# Altium schematic sheet ChildPCBRocketV4.SchDoc(ChildPCBRocketV4)
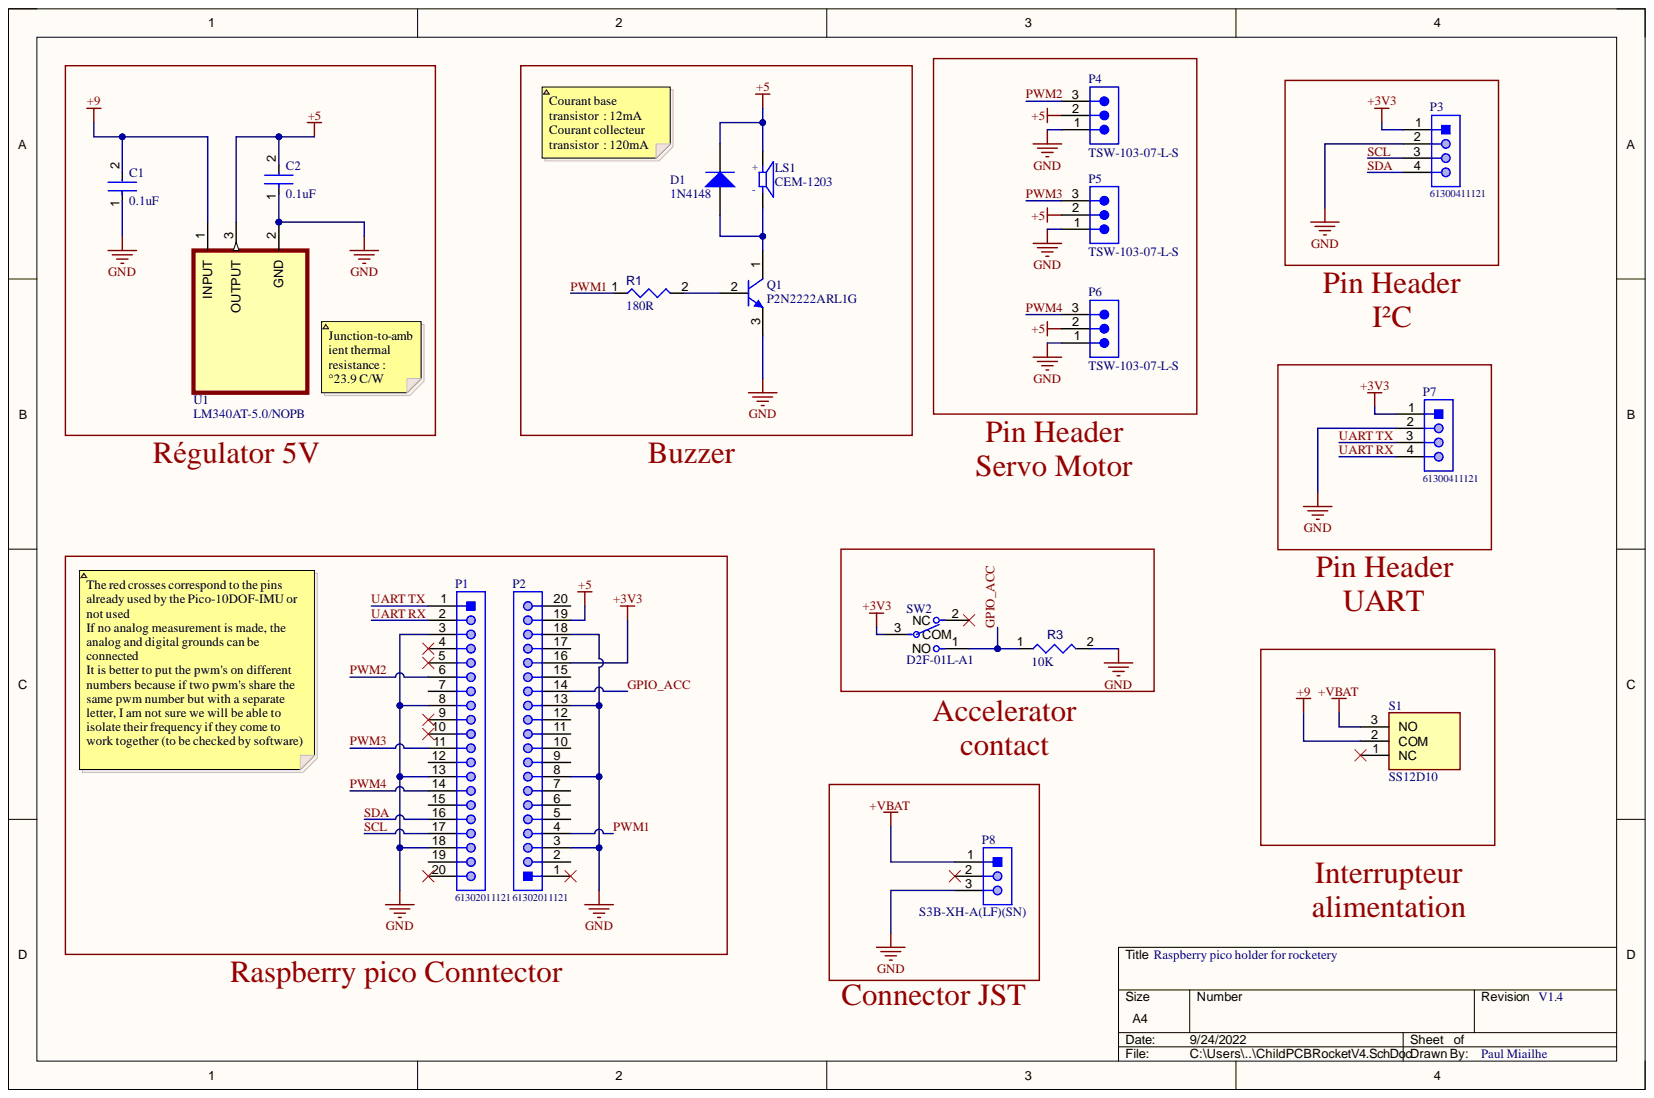
[diagram p0: ChildPCBRocketV4.SchDoc]

## Parts
C1  0.1uF  [FP-RCE1K1-2_5-MFG]
C2  0.1uF  [FP-RCE1K1-2_5-MFG]
D1  1N4148  [NXP-SOD27-2_V]
LS1  CEM-1203  [CUI_CEM-1203(42)]
P1  61302011121  [61302011121]
P2  61302011121  [61302011121]
P3  61300411121  [61300411121]
P4  TSW-103-07-L-S  [SMTC-TSW-103-07-X-S]
P5  TSW-103-07-L-S  [SMTC-TSW-103-07-X-S]
P6  TSW-103-07-L-S  [SMTC-TSW-103-07-X-S]
P7  61300411121  [61300411121]
P8  S3B-XH-A(LF)(SN)  [JST-S3B-XH-A(LF)(SN)_V]
Q1  P2N2222ARL1G  [ONSC-TO-92-3-29-11_AM_BL]
R1  value 180R  [RESA60-630X240]  (hidden comment: MFR-25FBF52-100R)
R3  value 10K  [RESA60-630X240]  (hidden comment: MFR-25FBF52-100R)
S1  SS12D10  [SS12D10]
SW2  D2F-01L-A1  [FP-D2F-01L-A1-MFG]
U1  LM340AT-5.0/NOPB  [TO254P1054X470X1955-3]

## Nets
+3V3: P2.16 P3.1 P7.1 SW2.3
+5: C2.2 D1.2 LS1.P P2.19 P4.2 P5.2 P6.2 U1.3
+9: C1.2 S1.2 U1.1
+VBAT: P8.1 S1.3
GND: C1.1 C2.1 P1.3 P1.8 P1.13 P1.18 P2.3 P2.8 P2.13 P2.18 P3.2 P4.1 P5.1 P6.1 P7.2 P8.3 Q1.3 R3.2 U1.2
GPIO_ACC: P2.14 R3.1 SW2.1
PWM1: P2.4 R1.1
PWM2: P1.6 P4.3
PWM3: P1.11 P5.3
PWM4: P1.14 P6.3
SCL: P1.17 P3.3
SDA: P1.16 P3.4
UART RX: P1.2 P7.4
UART TX: P1.1 P7.3
NetD1_1: D1.1 LS1.N Q1.1
NetQ1_2: Q1.2 R1.2

Not connected: P1 4 5 7 9 10 12 15 19 20; P2 1 2 5 6 7 9 10 11 12 15 17 20; P8 2; S1 1; SW2 2

## Library data of the parts
C1 C2 = CMP-06035-036150-1: Cap Ceramic Monolithic 220nF 50V 10% Thru-Hole Bulk; Dielectric: X7R; Lead Diameter: 0.5mm; Lead/Base Style: Formed Leads; Capacitance: 220nF; Case/Package: Radial; Component Kind: Standard; Height: 3.5mm; Height - Seated (Max): 0.138inch; Lead Pitch: 0.197inch; Length: 0.157inch; Library Name: Altium Content Vault; Max Operating Temperature: 125°C; Min Operating Temperature: -55°C; Mount: Through Hole; Package Quantity: 1; Packaging: Tape and Reel; Ratings: AEC-Q200; Resistor Type: Ceramic; Termination: Radial; Tolerance: 10%; Voltage Rating: 50V; Voltage Rating (DC): 50V; Width: 0.098inch
D1 = CMP-0648-00002-1: High-speed Diode, 100 V, 450 mA, 2-Pin SOD27, RoHS; Manufacturer: NXP Semiconductors; Cd: 4 pF Max.; Configuration: Single; IF: 200 mA Max.; IFRM: 450 mA; IFSM: 4 A Max.; IR (VR: 20 V)=25 nA; Trr: 4 ns Max.; VF (IF: 10 mA)=1 V; VR: 100 V Max.; Component Kind: Standard; ComponentLink1Description: Manufacturer URL; ComponentLink1URL: http://www.nxp.com/; ComponentLink2Description: Datasheet; ComponentLink2URL: http://www.nxp.com/documents/data_sheet/1N4148_1N4448.pdf; ComponentLink3Description: Package Specification; ComponentLink3URL: http://www.nxp.com/documents/data_sheet/1N4148_1N4448.pdf; DatasheetVersion: Aug-04; Library Name: Altium Content Vault; Mounting Technology: Through Hole; PackageDescription: 2-Pin Hermetically Sealed Glass Package, Axial Leaded, Dia 1.85 mm, Body Length 4.25 mm, Lead Spread 25.4 mm; PackageReference: SOD27; PackageVersion: Aug-04; PartNumber: 1N4148; RoHS: TRUE
LS1 = CEM-1203(42): 12 mm, 3.5 V, 85 dB, Through Hole, Magnetic Audio Transducer Buzzer; MF: {'manufacturer': u'CUI Devices'}; Availability: Unavailable; Component Kind: Standard; MP: CEM-1203(42); Package: None; Price: None
P1 P2 = CMP-1502-01102-1: THT Vertical Pin Header WR-PHD, Pitch 2.54 mm, Single Row, 20 pins; Manufacturer: Wurth Elektronik; Contact Resistance: 20mOhm; Gender: Male; Pin Number: 20; Pitch: 2.54mm; Rated Current: 3A; Withstanding Voltage: 500VAC; Working Voltage: 250VAC; Component Kind: Standard; Datasheet URL: https://katalog.we-online.de/em/datasheet/6130xx11121.pdf; Library Name: Altium Content Vault; Manufacturer URL: http://www.we-online.de; Mounting Technology: THT; Operating Temperature: -40to105degC; Orientation: Vertical; Package Reference: 61302011121; Packaging: Bag; Part Number: 61302011121; Row Number: Single; Publisher: WurthElektronik
P3 P7 = CMP-1502-01064-1: THT Vertical Pin Header WR-PHD, Pitch 2.54 mm, Single Row, 4 pins; Manufacturer: Wurth Elektronik; Contact Resistance: 20mOhm; Gender: Male; Pin Number: 4; Pitch: 2.54mm; Rated Current: 3A; Withstanding Voltage: 500VAC; Working Voltage: 250VAC; Component Kind: Standard; Datasheet URL: https://katalog.we-online.de/em/datasheet/6130xx11121.pdf; Library Name: Altium Content Vault; Manufacturer URL: http://www.we-online.de; Mounting Technology: THT; Operating Temperature: -40to105degC; Orientation: Vertical; Package Reference: 61300411121; Packaging: Bag; Part Number: 61300411121; Row Number: Single; Publisher: WurthElektronik
P4-P6 = CMP-1024-00334-1: 0.025" SQ Post Header, Through-hole, Vertical, -55 to 125 degC, 2.54 mm Pitch, 3-Pin, Male, RoHS; Manufacturer: Samtec; Pitch: 2.54 mm; Component Kind: Standard; ComponentLink1Description: Manufacturer URL; ComponentLink1URL: http://www.samtec.com; ComponentLink2Description: Datasheet; ComponentLink2URL: http://www.samtec.com/documents/webfiles/pdf/TSW_TH.PDF; ComponentLink3Description: Package Specification; ComponentLink3URL: http://www.samtec.com/documents/webfiles/cpdf/TSW-XXX-XX-XXX-X-XX-XXX-MKT.pdf; DatasheetVersion: F-214; Library Name: Altium Content Vault; Mounting Technology: Through-hole; Orientation: Vertical; PackageDescription: .025[0.64] SQ. Post Terminal Strip Assembly; PackageReference: TSW-103-07-X-S; PackageVersion: DM, 8/1988; PartNumber: TSW-103-07-L-S; RoHS: True; Temperature Range: -55 to 125 degC
P8 = CMP-1755-00006-1: Male Header, Pitch 2.5 mm, 1 x 3 Position, Height 6.1 mm, Tail Length 3.4 mm, -25 to 85 degC, RoHS, Bulk; Case\Package: DIP; Channels: 1; Frequency: 1.8 MHz; Max Ouput Current: -10 mA; Max Power Dissipation: 1 W; Max Supply Voltage: 15 V; Min Supply Voltage: 1 V; Nominal Supply Current: 170 uA; Component Kind: Standard; ComponentLink1Description: Manufacturer URL; ComponentLink1URL: http://www.jst.com/home2.html; ComponentLink2Description: Datasheet; ComponentLink2URL: http://www.jst-mfg.com/product/pdf/eng/eXH.pdf; DatasheetVersion: May-16; Height: 4.57 mm; Length: 9.81 mm; Library Name: Altium Content Vault; Max Operating Temperature: 70 degC; Min Operating Temperature: 0 degC; Mount: Through Hole; Package Quantity: 50; PackageDescription: Male Header, Pitch 2.5 mm, 1 x 3 Position, Height 6.1 mm, Tail Length 3.4 mm; PackageReference: S3B-XH-A(LF)(SN); Packaging: Rail/Tube; Pins: 8; Radiation Hardening: No; REACH SVHC: No SVHC; RoHSCompliant: true; Weight: 0.015535 oz; Width: 6.35 mm
Q1 = CMP-1048-01587-1: Amplifier Transistor, NPN Silicon, 3-Pin TO-92, Pb-Free, Tape and Reel; Manufacturer: On Semiconductor; fT Min (MHz): 300; IC Continuous (A): 0.6; Component Kind: Standard; ComponentLink1Description: Manufacturer URL; ComponentLink1URL: http://www.onsemi.com/; ComponentLink2Description: Datasheet; ComponentLink2URL: http://www.onsemi.com/pub_link/Collateral/P2N2222A-D.PDF; ComponentLink3Description: Package Specification; ComponentLink3URL: http://www.onsemi.com/pub_link/Collateral/MAC97-D.PDF; DatasheetVersion: Rev. 7; Library Name: Altium Content Vault; Mounting Technology: Through Hole; PackageDescription: 3-Pin Transistor Outline, Body 4.825 x 3.685 mm, Pitch 1.27 mm; PackageReference: TO-92-3-29-11_AM_BL; PackageVersion: Rev. AM; Packing: Tape and Reel; PartNumber: P2N2222ARL1G; Polarity: NPN; RoHS: TRUE
R1 R3 = CMP-009-00046-4: Axial Resistor, 100 Ohm, +/- 1%, 0.25 W, -55 to 155 degC, 2-Pin THD, RoHS, Bulk; Manufacturer: Yageo; Power: 250mW; Voltage Rating: 250V; Case/Package: Axial; Component Kind: Standard; Composition: CarbonFilm; Datasheet URL: http://www.yageo.com/documents/recent/Yageo%20LR_MFR_2013.pdf; Datasheet Version: Apr-13; Manufacturer URL: http://www.yageo.com/; Max Operating Temperature: 155°C; Min Operating Temperature: -55°C; Mounting Technology: TH; Package Description: 2-Pin Axial Lead, Dia 2.4 mm, Body Length 6.3 mm; Package Quantity: 200; Package Reference: MFR-25; Packaging: Bulk; RoHS Compliant: True; Tolerance: 1%; Library Name: Saint-Étienne School of Mines- Mines Space
S1 = SS12D10: Switch; Manufacturer_Name: DAIER; Component Kind: Standard; Datasheet Link: https://www.chinadaier.com/slide-switch-spdt/; Height: 9.91mm; Manufacturer_Part_Number: SS12D10
SW2 = CMP-08833-000018-1: SWITCH SNAP ACT SPDT 100MA 30V; Differential Travel: 0.02inch; Electrical Life: 30000Cycles; Mechanical Life: 1000000Cycles; Operating Force: 0.78N; Operating Position: 0.268inch; Overtravel: 0.022inch; Ratings: IP40; Switch Function: On-Mom; Component Kind: Standard; Contact Material: Gold; Current Rating: 100mA; Library Name: Altium Content Vault; Max Operating Temperature: 65°C; Max Voltage Rating (DC): 30V; Min Operating Temperature: -25°C; Mount: Through Hole; Orientation: Right Angle; Package Quantity: 450; Packaging: Bulk; Radiation Hardening: No; RoHS Compliant: Yes; Series: D2F; Termination: PC Pin; Throw Configuration: SPDT; Voltage Rating (DC): 30V
U1 = LM340AT-5.0/NOPB: MF: {'manufacturer': u'Texas Instruments'}; Availability: Unavailable; Component Kind: Standard; MP: LM340AT-5.0/NOPB; Package: None; Price: None
